# Altium schematic sheet LTE_MCU_Rev01.SchDoc
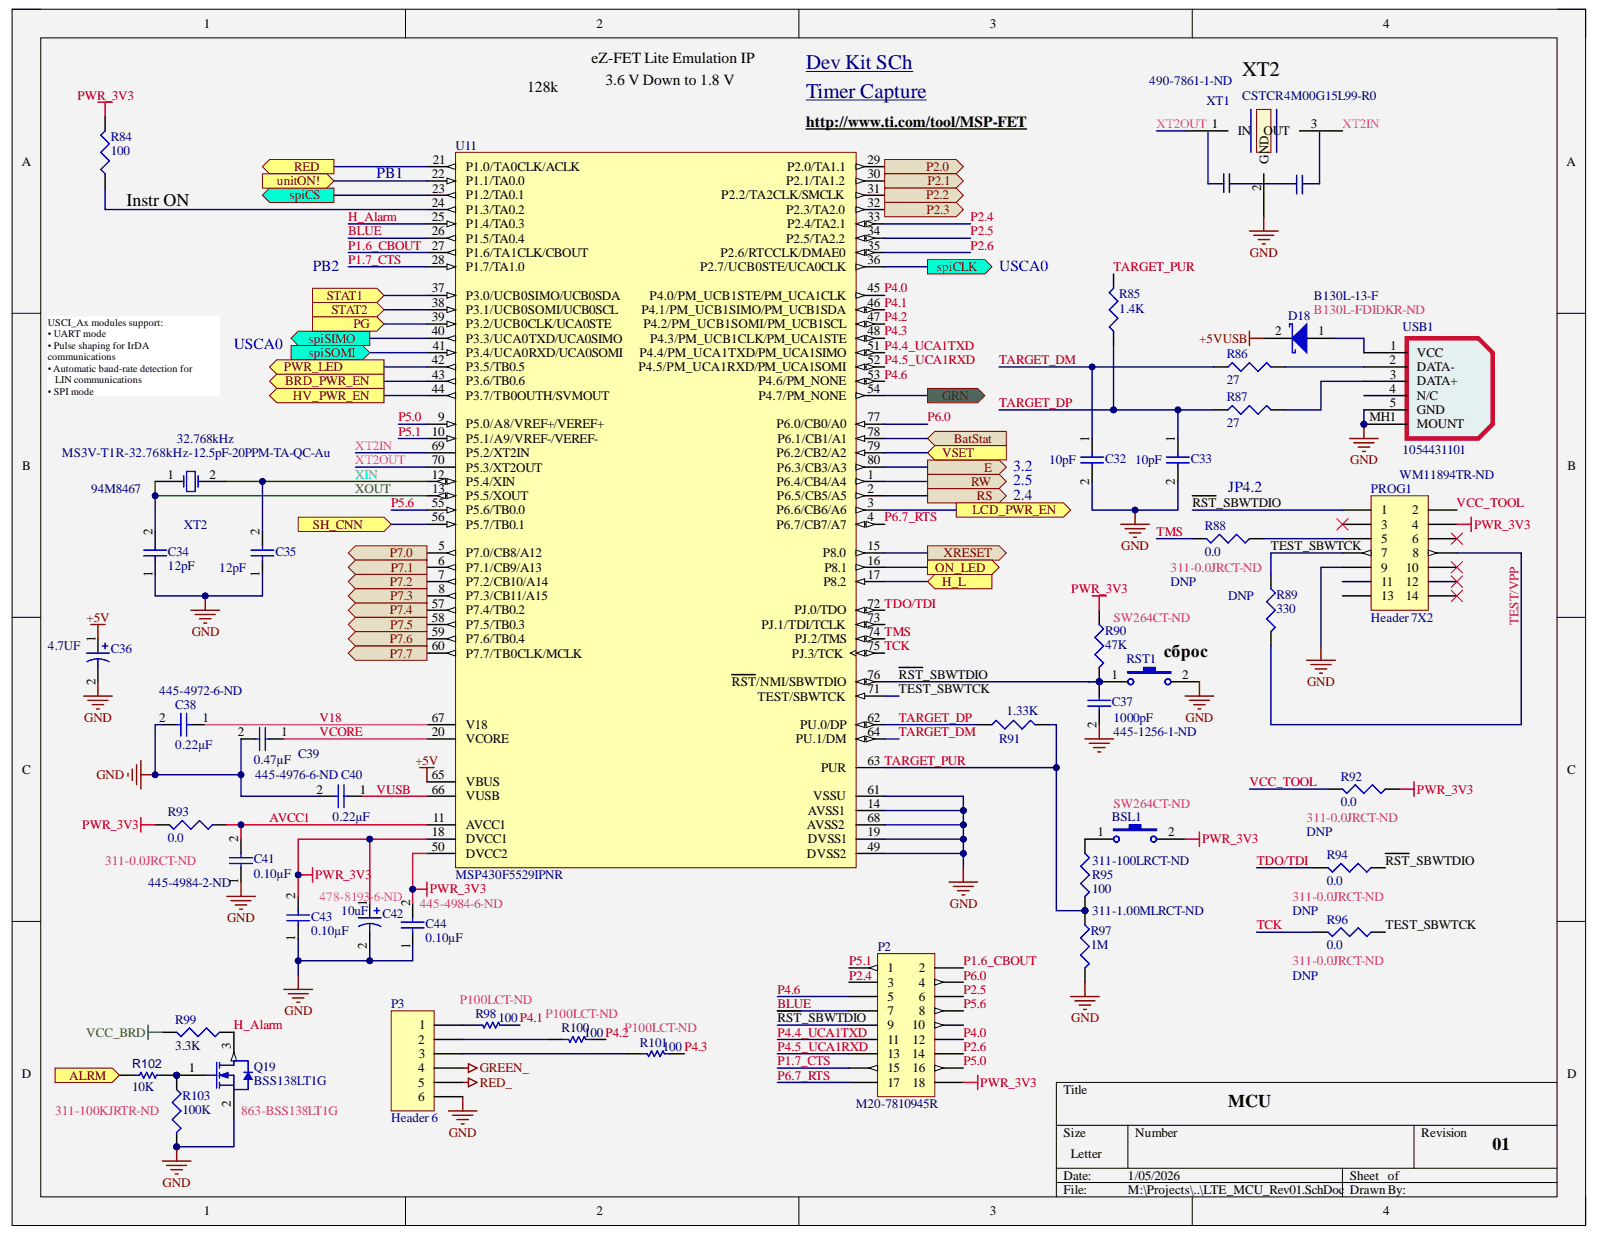
[diagram p0: LTE_MCU_Rev01.SchDoc]

## Parts
BSL1  Digi-Key Part Number: SW264CT-ND  [DTST-61K-Q_Rev01]  (hidden comment: B3F-6022)
C32  value 10pF  [0402 (1005 Metric)]  (hidden comment: C1005C0G1H100D050BA)
C33  value 10pF  [0402 (1005 Metric)]  (hidden comment: C1005C0G1H100D050BA)
C34  value 12pF  [0402 (1005 Metric)]  (hidden comment: C1005C0G1H120J050BA)
C35  value 12pF  [0402 (1005 Metric)]  (hidden comment: C1005C0G1H120J050BA)
C36  value 4.7UF  [1206 (3216 Metric)]  (hidden comment: T491A475K010AT)
C37  value 1000pF  Digi-Key Part Number: 445-1256-1-ND  [0402 (1005 Metric)]  (hidden comment: C1005X7R1H102K050BA)
C38  value 0.22µF  Supplier Part Number 2: 445-4972-6-ND  [0402 (1005 Metric)]  (hidden comment: C1005X5R1C224K050BB)
C39  value 0.47µF  [0402 (1005 Metric)]  (hidden comment: C1005X5R1C474K050BC)
C40  value 0.22µF  [0402 (1005 Metric)]  (hidden comment: C1005X5R1C224K050BB)
C41  value 0.10µF  Supplier Part Number 2: 445-4984-2-ND  [0402 (1005 Metric)]  (hidden comment: C1005X5R1A104M050BA)
C42  value 10uF  Supplier Part Number 2: 478-8193-6-ND  [1206 (3216 Metric)]  (hidden comment: F931A106MAA)
C43  value 0.10µF  [0402 (1005 Metric)]  (hidden comment: C1005X5R1A104M050BA)
C44  value 0.10µF  Supplier Part Number 2: 445-4984-6-ND  [0402 (1005 Metric)]  (hidden comment: C1005X5R1A104M050BA)
D18  B130L-13-F  Supplier Part Number 1: B130L-FDIDKR-ND  [SMA]
P2  M20-7810945R  [M20-7810945R]
P3  Header 6  [HDR1X6]
PROG1  Header 7X2  [HDR2X7]
Q19  BSS138LT1G  Supplier Part Number 1: 863-BSS138LT1G  [ONSC-SOT-23-3-318-08_V]
R84  value 100  [SMD RES 1005]  (hidden comment: RC0402FR-07100RL)
R85  value 1.4K  [SMD RES 1005]  (hidden comment: RC0402FR-071K47L)
R86  value 27  [SMD RES 1005]  (hidden comment: RC0402JR-0727RL)
R87  value 27  [SMD RES 1005]  (hidden comment: RC0402JR-0727RL)
R88  value 0.0  [SMD RES 1005]  (hidden comment: RC0402JR-070RL)
R89  value 330  Note: DNP  [SMD RES 1005]
R90  value 47K  [SMD RES 1005]  (hidden comment: RC0402FR-0747KL)
R91  value 1.33K  [SMD RES 1005]  (hidden comment: RC0402FR-071K33L)
R92  value 0.0  Digi-Key Part Number: 311-0.0JRCT-ND  [SMD RES 1005]  (hidden comment: RC0402JR-070RL)
R93  value 0.0  [SMD RES 1005]  (hidden comment: RC0402JR-070RL)
R94  value 0.0  [SMD RES 1005]  (hidden comment: RC0402JR-070RL)
R95  value 100  Digi-Key Part Number: 311-100LRCT-ND  [SMD RES 1005]  (hidden comment: RC0402FR-07100RL)
R96  value 0.0  Digi-Key Part Number: 311-0.0JRCT-ND  Note: DNP  [SMD RES 1005]  (hidden comment: RC0402JR-070RL)
R97  value 1M  Digi-Key Part Number: 311-1.00MLRCT-ND  [SMD RES 1005]  (hidden comment: RC0402FR-071ML)
R98  value 100  Digi-Key Part Number: P100LCT-ND  [0603 (1608 metric)]  (hidden comment: ERJ-2RKF1000X)
R99  value 3.3K  [SMD RES 1005]
R100  value 100  Digi-Key Part Number: P100LCT-ND  [0603 (1608 metric)]  (hidden comment: ERJ-2RKF1000X)
R101  value 100  Digi-Key Part Number: P100LCT-ND  [0603 (1608 metric)]  (hidden comment: ERJ-2RKF1000X)
R102  value 10K  [0402 (1005 Metric)]  (hidden comment: RC0402JR-0710KL)
R103  value 100K  Supplier Part Number 1: 311-100KJRTR-ND  [SMD RES 1005]  (hidden comment: RC0402JR-07100KL)
RST1  B3F-6022  [DTST-61K-Q_Rev01]  (value not drawn)
U11  MSP430F5529IPNR  [PN0080A_L]
USB1  1054431101  [1054431101]
XT1  CSTCR4M00G15L99-R0  Digi-Key Part Number: 490-7861-1-ND  [CSTCR4M00G15L99-R0]
XT2  MS3V-T1R-32.768kHz-12.5pF-20PPM-TA-QC-Au  value 32.768kHz  Supplier Part Number 1: 94M8467  [MS3V-T1R]

## Ports
ALRM, BatStat, BRD_PWR_EN, E, GRN, H_L, HV_PWR_EN, LCD_PWR_EN, ON_LED, P2.0, P2.1, P2.2, P2.3, P7.0, P7.1, P7.2, P7.3, P7.4, P7.5, P7.6, P7.7, PG, PWR_LED, RED, RS, RW, SH_CNN, spiCLK, spiCS, spiSIMO, spiSOMI, STAT1, STAT2, unitON!, VSET, XRESET

## Nets
+5V: C36.1 U11.65(VBUS)
+5VUSB: D18.2
GND: C32.2 C33.2 C34.1 C35.1 C36.2 C37.2 C38.2 C39.2 C40.2 C41.1 C42.2 C43.1 C44.1 P3.6 PROG1.9 Q19.2 R97.2 R103.2 RST1.2 U11.14(AVSS1) U11.19(DVSS1) U11.49(DVSS2) U11.61(VSSU) U11.68(AVSS2) USB1.5(GND) USB1.MH1(MOUNT) XT1.2
GREEN_: P3.4
PWR_3V3: BSL1.2 C42.1 C43.2 C44.2 P2.18 PROG1.4 R84.2 R90.1 R92.1 R93.2 U11.18(DVCC1) U11.50(DVCC2)
RED_: P3.5
VCC_BRD: R99.2
ALRM: R102.2 ; port ALRM
AVCC1: C41.2 R93.1 U11.11(AVCC1)
BatStat: U11.78(P6.1/CB1/A1) ; port BatStat
BLUE: P2.7 U11.26(P1.5/TA0.4)
BRD_PWR_EN: U11.43(P3.6/TB0.6) ; port BRD_PWR_EN
E: U11.80(P6.3/CB3/A3) ; port E
GRN: U11.54(P4.7/PM_NONE) ; port GRN
H_Alarm: Q19.3 R99.1 U11.25(P1.4/TA0.3)
H_L: U11.17(P8.2) ; port H_L
HV_PWR_EN: U11.44(P3.7/TB0OUTH/SVMOUT) ; port HV_PWR_EN
LCD_PWR_EN: U11.3(P6.6/CB6/A6) ; port LCD_PWR_EN
ON_LED: U11.16(P8.1) ; port ON_LED
P1.6_CBOUT: P2.2 U11.27(P1.6/TA1CLK/CBOUT)
P1.7_CTS: P2.15 U11.28(P1.7/TA1.0)
P2.0: U11.29(P2.0/TA1.1) ; port P2.0
P2.1: U11.30(P2.1/TA1.2) ; port P2.1
P2.2: U11.31(P2.2/TA2CLK/SMCLK) ; port P2.2
P2.3: U11.32(P2.3/TA2.0) ; port P2.3
P2.4: P2.3 U11.33(P2.4/TA2.1)
P2.5: P2.6 U11.34(P2.5/TA2.2)
P2.6: P2.14 U11.35(P2.6/RTCCLK/DMAE0)
P4.0: P2.12 U11.45(P4.0/PM_UCB1STE/PM_UCA1CLK)
P4.1: R98.1 U11.46(P4.1/PM_UCB1SIMO/PM_UCB1SDA)
P4.2: R100.1 U11.47(P4.2/PM_UCB1SOMI/PM_UCB1SCL)
P4.3: R101.1 U11.48(P4.3/PM_UCB1CLK/PM_UCA1STE)
P4.4_UCA1TXD: P2.11 U11.51(P4.4/PM_UCA1TXD/PM_UCA1SIMO)
P4.5_UCA1RXD: P2.13 U11.52(P4.5/PM_UCA1RXD/PM_UCA1SOMI)
P4.6: P2.5 U11.53(P4.6/PM_NONE)
P5.0: P2.16 U11.9(P5.0/A8/VREF+/VEREF+)
P5.1: P2.1 U11.10(P5.1/A9/VREF-/VEREF-)
P5.6: P2.8 U11.55(P5.6/TB0.0)
P6.0: P2.4 U11.77(P6.0/CB0/A0)
P6.7_RTS: P2.17 U11.4(P6.7/CB7/A7)
P7.0: U11.5(P7.0/CB8/A12) ; port P7.0
P7.1: U11.6(P7.1/CB9/A13) ; port P7.1
P7.2: U11.7(P7.2/CB10/A14) ; port P7.2
P7.3: U11.8(P7.3/CB11/A15) ; port P7.3
P7.4: U11.57(P7.4/TB0.2) ; port P7.4
P7.5: U11.58(P7.5/TB0.3) ; port P7.5
P7.6: U11.59(P7.6/TB0.4) ; port P7.6
P7.7: U11.60(P7.7/TB0CLK/MCLK) ; port P7.7
PG: U11.39(P3.2/UCB0CLK/UCA0STE) ; port PG
PWR_LED: U11.42(P3.5/TB0.5) ; port PWR_LED
~{RST}_SBWTDIO: C37.1 P2.9 PROG1.1 R90.2 R94.1 RST1.1 U11.76(RST/NMI/SBWTDIO)
RED: U11.21(P1.0/TA0CLK/ACLK) ; port RED
RS: U11.2(P6.5/CB5/A5) ; port RS
RW: U11.1(P6.4/CB4/A4) ; port RW
SH_CNN: U11.56(P5.7/TB0.1) ; port SH_CNN
spiCLK: U11.36(P2.7/UCB0STE/UCA0CLK) ; port spiCLK
spiCS: U11.23(P1.2/TA0.1) ; port spiCS
spiSIMO: U11.40(P3.3/UCA0TXD/UCA0SIMO) ; port spiSIMO
spiSOMI: U11.41(P3.4/UCA0RXD/UCA0SOMI) ; port spiSOMI
STAT1: U11.37(P3.0/UCB0SIMO/UCB0SDA) ; port STAT1
STAT2: U11.38(P3.1/UCB0SOMI/UCB0SCL) ; port STAT2
TARGET_DM: C32.1 R86.1 U11.64(PU.1/DM)
TARGET_DP: C33.1 R85.1 R87.1 R91.1 U11.62(PU.0/DP)
TARGET_PUR: R85.2 R91.2 R95.2 R97.1 U11.63(PUR)
TCK: R96.2 U11.75(PJ.3/TCK)
TDO/TDI: R94.2 U11.72(PJ.0/TDO)
TEST_SBWTCK: PROG1.7 R89.1 R96.1 U11.71(TEST/SBWTCK)
TMS: R88.2 U11.74(PJ.2/TMS)
unitON!: U11.22(P1.1/TA0.0) ; port unitON!
V18: C38.1 U11.67(V18)
VCC_TOOL: PROG1.2 R92.2
VCORE: C39.1 U11.20(VCORE)
VSET: U11.79(P6.2/CB2/A2) ; port VSET
VUSB: C40.1 U11.66(VUSB)
XIN: C35.2 U11.12(P5.4/XIN) XT2.2
XOUT: C34.2 U11.13(P5.5/XOUT) XT2.1
XRESET: U11.15(P8.0) ; port XRESET
XT2IN: U11.69(P5.2/XT2IN) XT1.3
XT2OUT: U11.70(P5.3/XT2OUT) XT1.1
NetBSL1_1: BSL1.1 R95.1
NetD18_1: D18.1 USB1.1(VCC)
NetP2_10: P2.10
NetP3_1: P3.1 R98.2
NetP3_2: P3.2 R100.2
NetP3_3: P3.3 R101.2
NetPROG1_3: PROG1.3
NetPROG1_5: PROG1.5 R88.1
NetPROG1_6: PROG1.6
NetPROG1_8: PROG1.8 R89.2
NetPROG1_10: PROG1.10
NetPROG1_11: PROG1.11
NetPROG1_12: PROG1.12
NetPROG1_13: PROG1.13
NetPROG1_14: PROG1.14
NetQ19_1: Q19.1 R102.1 R103.1
NetR84_1: R84.1 U11.24(P1.3/TA0.2)
NetR86_2: R86.2 USB1.2(DATA-)
NetR87_2: R87.2 USB1.3(DATA+)
NetU11_73: U11.73(PJ.1/TDI/TCLK)
NetUSB1_4: USB1.4(N/C)

## Library data of the parts
BSL1 RST1 = SW-PB: SWITCH TACTILE SPST-NO 0.05A 24V; Manufacturer: Omron Electronics Inc-EMC Div; Component Kind: Standard; Cost: 0.36304; LatestRevisionDate: 17-Jul-2002; LatestRevisionNote: Re-released for DXP Platform.; Library Name: Miscellaneous Devices.IntLib; Manufacturer Part Number: B3F-6022; PackageDescription: Switch; 2 Leads; PackageReference: SPST-2; Published: 24-Mar-1999; Supplier 1: Digi-Key; Supplier Part Number 1: SW264CT-ND; Publisher: Altium Limited
  RST1: Digi-Key Part Number: SW264CT-ND
C32 C33 = Cap Semi: 10pF ±0.5pF 50V C0G, NP0 Ceramic Capacitor -55°C ~ 125°C Surface Mount, MLCC 0402 (1005 Metric) 0.039" L x 0.020" W (1.00mm x 0.50mm); Manufacturer: TDK Corporation; Component Kind: Standard; Cost: 0.02558; Digi-Key Part Number: 445-1235-1-ND; Manufacturer Part Number: C1005C0G1H100D050BA; PackageDescription: Chip Capacitor; PackageReference: 0402; Signal Integrity: Cap Semi; Simulation: CAP; Supplier 1: Digi-Key; Library Name: TempProbe.SchLib
C34 C35 = Cap Semi: CAP CER 12PF 50V C0G 0402; Manufacturer: TDK Corporation; Component Kind: Standard; Cost: 0.02558; Digi-Key Part Number: 445-1236-1-ND; Manufacturer Part Number: C1005C0G1H120J050BA; PackageDescription: Chip Capacitor; PackageReference: 0402; Signal Integrity: Cap Semi; Simulation: CAP; Supplier 1: Digi-Key; Library Name: TempProbe.SchLib
C36 = Cap Pol1: CAP TANT 4.7UF 10V 10% 1206; Manufacturer: Kemet; Component Kind: Standard; Cost: 0.09660; Digi-Key Part Number: 399-3696-1-ND; LatestRevisionDate: 17-Jul-2002; LatestRevisionNote: Re-released for DXP Platform.; Library Name: Miscellaneous Devices.IntLib; Manufacturer Part Number: T491A475K010AT; PackageDescription: Polarized Capacitor (Radial); 2 Leads; PackageReference: RB7.6-15; Published: 8-Jun-2000; Signal Integrity: Cap Pol; Simulation: CAP; Supplier 1: Mouser; Publisher: Altium Limited
C37 = Cap Semi: 1000pF ±10% 50V X7R Ceramic Capacitor -55°C ~ 125°C Surface Mount, MLCC 0402 (1005 Metric) 0.039" L x 0.020" W (1.00mm x 0.50mm); Manufacturer: TDK Corporation; Component Kind: Standard; Cost: 0.02558; Manufacturer Part Number: C1005X7R1H102K050BA; PackageDescription: Chip Capacitor; PackageReference: 0402; Signal Integrity: Cap Semi; Simulation: CAP; Supplier 1: Digi-Key; Supplier 2: Digi-Key; Supplier Part Number 2: 445-1256-1-ND; Library Name: TempProbe.SchLib
C38 C40 = Cap Semi: 0.22µF ±10% 16V X5R Ceramic Capacitor -55°C ~ 85°C Surface Mount, MLCC 0402 (1005 Metric) 0.039" L x 0.020" W (1.00mm x 0.50mm); Manufacturer: TDK Corporation; Component Kind: Standard; Cost: 0.02558; Digi-Key Part Number: 445-4972-1-ND; Manufacturer Part Number: C1005X5R1C224K050BB; PackageDescription: Chip Capacitor; PackageReference: 0402; Signal Integrity: Cap Semi; Simulation: CAP; Supplier 1: Digi-Key; Library Name: TempProbe.SchLib
  C38: Supplier 2: Digi-Key
C39 C41 C43 C44 = Cap Semi: 0.10µF ±20% 10V X5R Ceramic Capacitor -55°C ~ 85°C Surface Mount, MLCC 0402 (1005 Metric) 0.039" L x 0.020" W (1.00mm x 0.50mm); Manufacturer: TDK Corporation; Component Kind: Standard; PackageDescription: Chip Capacitor; PackageReference: 0402; Signal Integrity: Cap Semi; Simulation: CAP; Supplier 1: Digi-Key; Library Name: TempProbe.SchLib
  C39: Digi-Key Part Number: 445-4976-1-ND; Manufacturer Part Number: C1005X5R1C474K050BC; Supplier 2: Digi-Key; Supplier Part Number 2: 445-4976-6-ND
  C41 C44: Cost: 0.02558; Digi-Key Part Number: 445-4984-1-ND; Manufacturer Part Number: C1005X5R1A104M050BA; Supplier 2: Digi-Key
  C43: Cost: 0.02558; Digi-Key Part Number: 445-4984-1-ND; Manufacturer Part Number: C1005X5R1A104M050BA
C42 = Cap Pol1: CAP TANT 10UF 10V 20% 1206; Manufacturer: AVX Corporation; Component Kind: Standard; Cost: 0.09660; Digi-Key Part Number: 478-8193-1-ND; LatestRevisionDate: 17-Jul-2002; LatestRevisionNote: Re-released for DXP Platform.; Library Name: Miscellaneous Devices.IntLib; Manufacturer Part Number: F931A106MAA; PackageDescription: Polarized Capacitor (Radial); 2 Leads; PackageReference: RB7.6-15; Published: 8-Jun-2000; Signal Integrity: Cap Pol; Simulation: CAP; Supplier 1: Mouser; Supplier 2: Digi-Key; Publisher: Altium Limited
D18 = D Schottky: DIODE SCHOTTKY 30V 1A SMA; Manufacturer: Diodes Incorporated; Code_JEDEC: DO-214-AA; Component Kind: Standard; ComponentLink1Description: Datasheet; ComponentLink1URL: http://www.diodes.com/datasheets/ds30151.pdf; ComponentLink2Description: Folder; Cost: 0.12154; Digi-Key Part Number: B130L-FDICT-ND; LatestRevisionDate: 17-Jul-2002; LatestRevisionNote: Re-released for DXP Platform.; Library Name: Miscellaneous Devices.IntLib; Manufacturer Part Number: B130L-13-F; PackageDescription: DO-214-AA/SMB; 2 C-Bend Leads; Body 5.3 x 3.6 mm, inc. leads (LxW); PackageReference: SMB; Published: 8-Jun-2000; Signal Integrity: D Schottky; Simulation: SKYDIODE; Supplier 1: Digi-Key; ComponentLink2URL: C:\Users\rchak\Documents\Projects\CC3200 Development\Docs\Datasheets\Diodes; Publisher: Altium Limited
P2 = Header 9X2: CONN RCPT 2.54" SMD DUAL 18POS; Manufacturer: Harwin Inc.; Component Kind: Standard; Digi-Key Part Number: 952-3226-2-ND; LatestRevisionDate: 17-Jul-2002; LatestRevisionNote: Re-released for DXP Platform.; Library Name: Miscellaneous Connectors.IntLib; Manufacturer Part Number: M20-7810945R; Signal Integrity: Connector; Publisher: Altium Limited
P3 = Header 6: Header, 6-Pin; Component Kind: Standard; LatestRevisionDate: 17-Jul-2002; LatestRevisionNote: Re-released for DXP Platform.; Library Name: Miscellaneous Connectors.IntLib; Signal Integrity: Connector; Publisher: Altium Limited
PROG1 = Header 7X2: BERGSTIK II .100" DR STRAIGHT; Manufacturer: Amphenol FCI; Manufacturer Part Number: 67996-114HLF; Component Kind: Standard; Digi-Key Part Number: 609-3491-ND; LatestRevisionDate: 17-Jul-2002; LatestRevisionNote: Re-released for DXP Platform.; Library Name: Miscellaneous Connectors.IntLib; Signal Integrity: Connector; Publisher: Altium Limited
Q19 = CMP-1058-00767-1: MOSFET N-CH 50V 200MA SOT-23; Manufacturer: On Semiconductor; Channel Polarity: N Channel; Ciss Typ (pF): 40; Configuration: Single; Coss Typ (pF): 12; Crss Typ (pF): 3.5; ID Max (A): 0.2; Packing: Tape and Reel; PD Max (W): 0.225; V(BR)DSS Min (V): 50; VGS Max (V): 20; VGS(th) Max (V): 1.5; Component Kind: Standard; ComponentLink1Description: Manufacturer URL; ComponentLink1URL: http://www.onsemi.com/; ComponentLink2Description: Datasheet; ComponentLink2URL: http://www.onsemi.com/pub_link/Collateral/BSS138LT1-D.PDF; ComponentLink3Description: Package Specification; ComponentLink3URL: http://www.onsemi.cn/pub_link/Collateral/318-08.PDF; Cost: 0.04997; DatasheetVersion: Rev. 8; Digi-Key Part Number: BSS138LT1GOSCT-ND; Library Name: Altium Content Vault; Mounting Technology: Surface Mount; Package Type: SOT-23-3; PackageDescription: 3-Pin Small Outline Transistor, Body 2.92 x 1.3 mm, Pitch 0.95 mm; PackageReference: SOT-23-3-318-08; PackageVersion: Rev. AP, 11/2009; PartNumber: BSS138LT1G; RoHS: Pb-Free; Supplier 1: Mouser
R84 R95 = Res3: RES SMD 100 OHM 1% 1/16W 0402; Manufacturer: Yageo; Component Kind: Standard; Cost: 0.00384; LatestRevisionDate: 29-May-2009; LatestRevisionNote: IPC-7351 Footprint Added.; Library Name: Miscellaneous Devices.IntLib; Manufacturer Part Number: RC0402FR-07100RL; PackageDescription: Chip Resistor; PackageReference: J1-0603; Published: 8-Jun-2000; Signal Integrity: Res; Simulation: RESISTOR; Supplier 1: Digi-Key; Supplier 2: Digi-Key; Supplier Part Number 2: 311-100LRCT-ND; Publisher: Altium Limited
  R84: Digi-Key Part Number: 311-100LRCT-ND
R85 = Res3: RES SMD 1.47K OHM 1% 1/16W 0402; Manufacturer: Yageo; Component Kind: Standard; Cost: 0.00384; Digi-Key Part Number: YAG3038CT-ND; LatestRevisionDate: 29-May-2009; LatestRevisionNote: IPC-7351 Footprint Added.; Library Name: Miscellaneous Devices.IntLib; Manufacturer Part Number: RC0402FR-071K47L; PackageDescription: Chip Resistor; PackageReference: J1-0603; Published: 8-Jun-2000; Signal Integrity: Res; Simulation: RESISTOR; Supplier 1: Digi-Key; Publisher: Altium Limited
R86 R87 = Res3: RES SMD 27 OHM 5% 1/16W 0402; Manufacturer: Yageo; Component Kind: Standard; Cost: 0.00384; Digi-Key Part Number: 311-27JRCT-ND; LatestRevisionDate: 29-May-2009; LatestRevisionNote: IPC-7351 Footprint Added.; Library Name: Miscellaneous Devices.IntLib; Manufacturer Part Number: RC0402JR-0727RL; PackageDescription: Chip Resistor; PackageReference: J1-0603; Published: 8-Jun-2000; Signal Integrity: Res; Simulation: RESISTOR; Supplier 1: Digi-Key; Publisher: Altium Limited
R88 R92 R94 = Res3: RES SMD 0.0 OHM JUMPER 1/16W; Manufacturer: Yageo; Note: DNP; Component Kind: Standard; Cost: 0.00384; LatestRevisionDate: 29-May-2009; LatestRevisionNote: IPC-7351 Footprint Added.; Library Name: Miscellaneous Devices.IntLib; Manufacturer Part Number: RC0402JR-070RL; PackageDescription: Chip Resistor; PackageReference: J1-0603; Published: 8-Jun-2000; Signal Integrity: Res; Simulation: RESISTOR; Supplier 1: Digi-Key; Supplier Part Number 1: 311-0.0JRCT-ND; Publisher: Altium Limited
  R88 R94: Digi-Key Part Number: 311-0.0JRCT-ND
R89 = Res3: Component Kind: Standard; Cost: 0.00384; LatestRevisionDate: 29-May-2009; LatestRevisionNote: IPC-7351 Footprint Added.; Library Name: Miscellaneous Devices.IntLib; PackageDescription: Chip Resistor; PackageReference: J1-0603; Published: 8-Jun-2000; Signal Integrity: Res; Simulation: RESISTOR; Supplier 1: Digi-Key; Publisher: Altium Limited
R90 = Res3: RES SMD 47K OHM 1% 1/16W 0402; Manufacturer: Yageo; Component Kind: Standard; Cost: 0.00384; Digi-Key Part Number: 311-47.0KLRCT-ND; LatestRevisionDate: 29-May-2009; LatestRevisionNote: IPC-7351 Footprint Added.; Library Name: Miscellaneous Devices.IntLib; Manufacturer Part Number: RC0402FR-0747KL; PackageDescription: Chip Resistor; PackageReference: J1-0603; Published: 8-Jun-2000; Signal Integrity: Res; Simulation: RESISTOR; Supplier 1: Digi-Key; Publisher: Altium Limited
R91 = Res3: RES SMD 1.33K OHM 1% 1/16W 0402; Manufacturer: Yageo; Component Kind: Standard; Cost: 0.00384; Digi-Key Part Number: 311-1.33KLRCT-ND; LatestRevisionDate: 29-May-2009; LatestRevisionNote: IPC-7351 Footprint Added.; Library Name: Miscellaneous Devices.IntLib; Manufacturer Part Number: RC0402FR-071K33L; PackageDescription: Chip Resistor; PackageReference: J1-0603; Published: 8-Jun-2000; Signal Integrity: Res; Simulation: RESISTOR; Supplier 1: Digi-Key; Publisher: Altium Limited
R93 R96 = Res3: RES SMD 0.0 OHM JUMPER 1/16W; Manufacturer: Yageo; Component Kind: Standard; Cost: 0.00384; LatestRevisionDate: 29-May-2009; LatestRevisionNote: IPC-7351 Footprint Added.; Library Name: Miscellaneous Devices.IntLib; Manufacturer Part Number: RC0402JR-070RL; PackageDescription: Chip Resistor; PackageReference: J1-0603; Published: 8-Jun-2000; Signal Integrity: Res; Simulation: RESISTOR; Supplier 1: Digi-Key; Supplier Part Number 1: 311-0.0JRCT-ND; Publisher: Altium Limited
  R93: Digi-Key Part Number: 311-0.0JRCT-ND
R97 = Res3: RES SMD 1M OHM 1% 1/16W 0402; Manufacturer: Yageo; Component Kind: Standard; Cost: 0.00384; LatestRevisionDate: 29-May-2009; LatestRevisionNote: IPC-7351 Footprint Added.; Library Name: Miscellaneous Devices.IntLib; Manufacturer Part Number: RC0402FR-071ML; PackageDescription: Chip Resistor; PackageReference: J1-0603; Published: 8-Jun-2000; Signal Integrity: Res; Simulation: RESISTOR; Supplier 1: Digi-Key; Supplier 2: Digi-Key; Supplier Part Number 2: 311-1.00MLRCT-ND; Publisher: Altium Limited
R98 R100 R101 = Res1: RES SMD 100 OHM 1% 1/10W 0402; Manufacturer: Panasonic Electronic Components; Alternative: or equivalent; Assembly Note: Install; Class I: Passive; Class II: Resistor; Loc: Vendor; Protorype Price: 0.01500; Component Kind: Standard; Cost: 0.00496; Library Name: Miscellaneous Devices.IntLib; Manufacturer Part Number: ERJ-2RKF1000X; Price: 0.01050; Price for 100: 0.01050; Published: 8-Jun-2000; Purchased by: Vendor; Revision: July-2002: Re-released for DXP Platform.; Signal Integrity: Res1; Simulation: RESISTOR; Supplier 1: Digi-Key; Supplier Part Number 1: P100LCT-ND; Type: SMD; Publisher: Altium Limited
R99 = Res3: Manufacturer: Yageo; Component Kind: Standard; Cost: 0.00384; LatestRevisionDate: 29-May-2009; LatestRevisionNote: IPC-7351 Footprint Added.; Library Name: Miscellaneous Devices.IntLib; PackageDescription: Chip Resistor; PackageReference: J1-0603; Published: 8-Jun-2000; Signal Integrity: Res; Simulation: RESISTOR; Supplier 1: Digi-Key; Publisher: Altium Limited
R102 = Res1: RES SMD 10K OHM 5% 1/16W 0402; Manufacturer: Panasonic Electronic Components; Assembly Note: Install; Loc: Vendor; Component Kind: Standard; Cost: 0.00544; Digi-Key Part Number: 311-10KJRCT-ND; LatestRevisionDate: 17-Jul-2002; LatestRevisionNote: Re-released for DXP Platform.; Library Name: Miscellaneous Devices.IntLib; Manufacturer Part Number: RC0402JR-0710KL; PackageDescription: Axial Device, Thru-Hole; 2 Leads; 0.3 in Pin Spacing; PackageReference: AXIAL-0.3; Price for 50: 0.04820; Published: 8-Jun-2000; Signal Integrity: Res; Simulation: RESISTOR; Type: SMD; Publisher: Altium Limited
R103 = Res3: RES SMD 100K OHM 5% 1/16W 0402; Manufacturer: Yageo; Component Kind: Standard; Cost: 0.00172; Digi-Key Part Number: 311-100KJRCT-ND; LatestRevisionDate: 29-May-2009; LatestRevisionNote: IPC-7351 Footprint Added.; Library Name: Miscellaneous Devices.IntLib; Manufacturer Part Number: RC0402JR-07100KL; PackageDescription: Chip Resistor; PackageReference: J1-0603; Published: 8-Jun-2000; Signal Integrity: Res; Simulation: RESISTOR; Supplier 1: Digi-Key; Publisher: Altium Limited
U11 = CMP-0365-00413-2: IC MCU 16BIT 128KB FLASH 80LQFP; Manufacturer: Texas Instruments; ADC: 12-bit SAR; ADC Channels: 16; Brown Out Reset: Yes; DMA: 3; Eco-Plan: Green (RoHS & no Sb/Br); Flash(KB): 128; Frequency(MHz): 25; GPIO: 63; Multiplier: 32x32; SRAM(B): 8192; SubFamily: 5 Series; Timers - 16-bit: 4; USB: 1; USCI_A (UART/LIN/IrDA/SPI): 2; Code_JEDEC: MS-018; Comparators: Yes; Component Kind: Standard; ComponentLink1Description: ADC12; ComponentLink2Description: Foder; ComponentLink3Description: Package Specification; ComponentLink3URL: http://www.ti.com/lit/ml/mtqf010a/mtqf010a.pdf; ComponentLink4Description: Digital IO Module; ComponentLink5Description: Dev Kit; DatasheetVersion: SLAS590I; Digi-Key Part Number: 296-27306-1-ND; Library Name: Altium Content Vault; Manufacturer Part Number: MSP430F5529IPNR; Mounting Technology: Surface Mount; PackageDescription: 80-Pin Plastic Quad Flat Pack, Body 12 x 12 mm, Pitch 0.5 mm; PackageReference: PN0080A; PartNumber: MSP430F5529IPNR; Real-Time Clock: Yes; SVS: Yes; Temp Sensor: Yes; USCI_B (I2C & SPI): 2; Watchdog: Yes; ComponentLink1URL: C:\Users\Roman\Documents\Projects\GalaS-NDT\Galas-HV-Det_Rev-00\Docs\Datasheets\MSP430\ADC12_A.pdf; ComponentLink2URL: C:\Users\Roman\Documents\Projects\GalaS-NDT\Galas-HV-Det_Rev-00\Docs\Datasheets\MSP430; ComponentLink4URL: C:\Users\Roman\Documents\Projects\GalaS-NDT\Galas-HV-Det_Rev-00\Docs\Datasheets\MSP430\Digital IO Module.pdf; ComponentLink5URL: C:\Users\Roman\Documents\Projects\GalaS-NDT\Galas-HV-Det_Rev-00\Docs\Datasheets\MSP430\User Guide
USB1 = 1054431101: USB - micro B Receptacle Connector 5 Position Surface Mount, Right Angle, Horizontal; Manufacturer: Molex, LLC; Component Kind: Standard; ComponentLink1Description: C Datasheet; Digi-Key Part Number: WM11894CT-ND; Library Name: USB.SCHLIB; Manufacturer Part Number: 1054431101; Supplier 1: Digi-Key; Supplier Part Number 1: WM11894TR-ND
XT1 = CSTCR4M00G15L99-R0: CER RES 4.0000MHZ 39PF SMD; Manufacturer: Murata Electronics North America; Component Kind: Standard; ComponentLink1Description: C Datasheet; Library Name: Oscillator.SchLib; Manufacturer Part Number: CSTCR4M00G15L99-R0; Supplier 1: Digi-Key; Supplier Part Number 1: 490-7861-1-ND; ComponentLink1URL: C:\Users\Roman\Documents\Projects\GalaS-NDT\Galas-HV-Det_Rev-00\Docs\Datasheets\Oscillator\CSTCR4M00G15L99-R0.pdf
XT2 = XTAL: Crystals 32.768 kHz 12.5 pF +/-20 PPM -40/+85C; Manufacturer: Microcrystal; Component Kind: Standard; ComponentLink1Description: C Datasheet; LatestRevisionDate: 17-Jul-2002; LatestRevisionNote: Re-released for DXP Platform.; Library Name: Miscellaneous Devices.IntLib; Manufacturer Part Number: MS3V-T1R-32.768kHz-12.5pF-20PPM-TA-QC-Au; Mouser #: 428-200001-ML02; PackageDescription: Crystal, Thru-Hole; 2 Leads; Body 3.1 x 8.2 mm (Dia.xH); PackageReference: R38; Published: 8-Jun-2000; Simulation: XTAL; Supplier 1: Newark; ComponentLink1URL: C:\Users\Roman\Documents\Projects\GalaS-NDT\Galas-HV-Det_Rev-00\Docs\Datasheets\Oscillator\MS3V-T1R-1085741.pdf; Publisher: Altium Limited
